# Altium schematic sheet Alt.SchDoc(Alt)
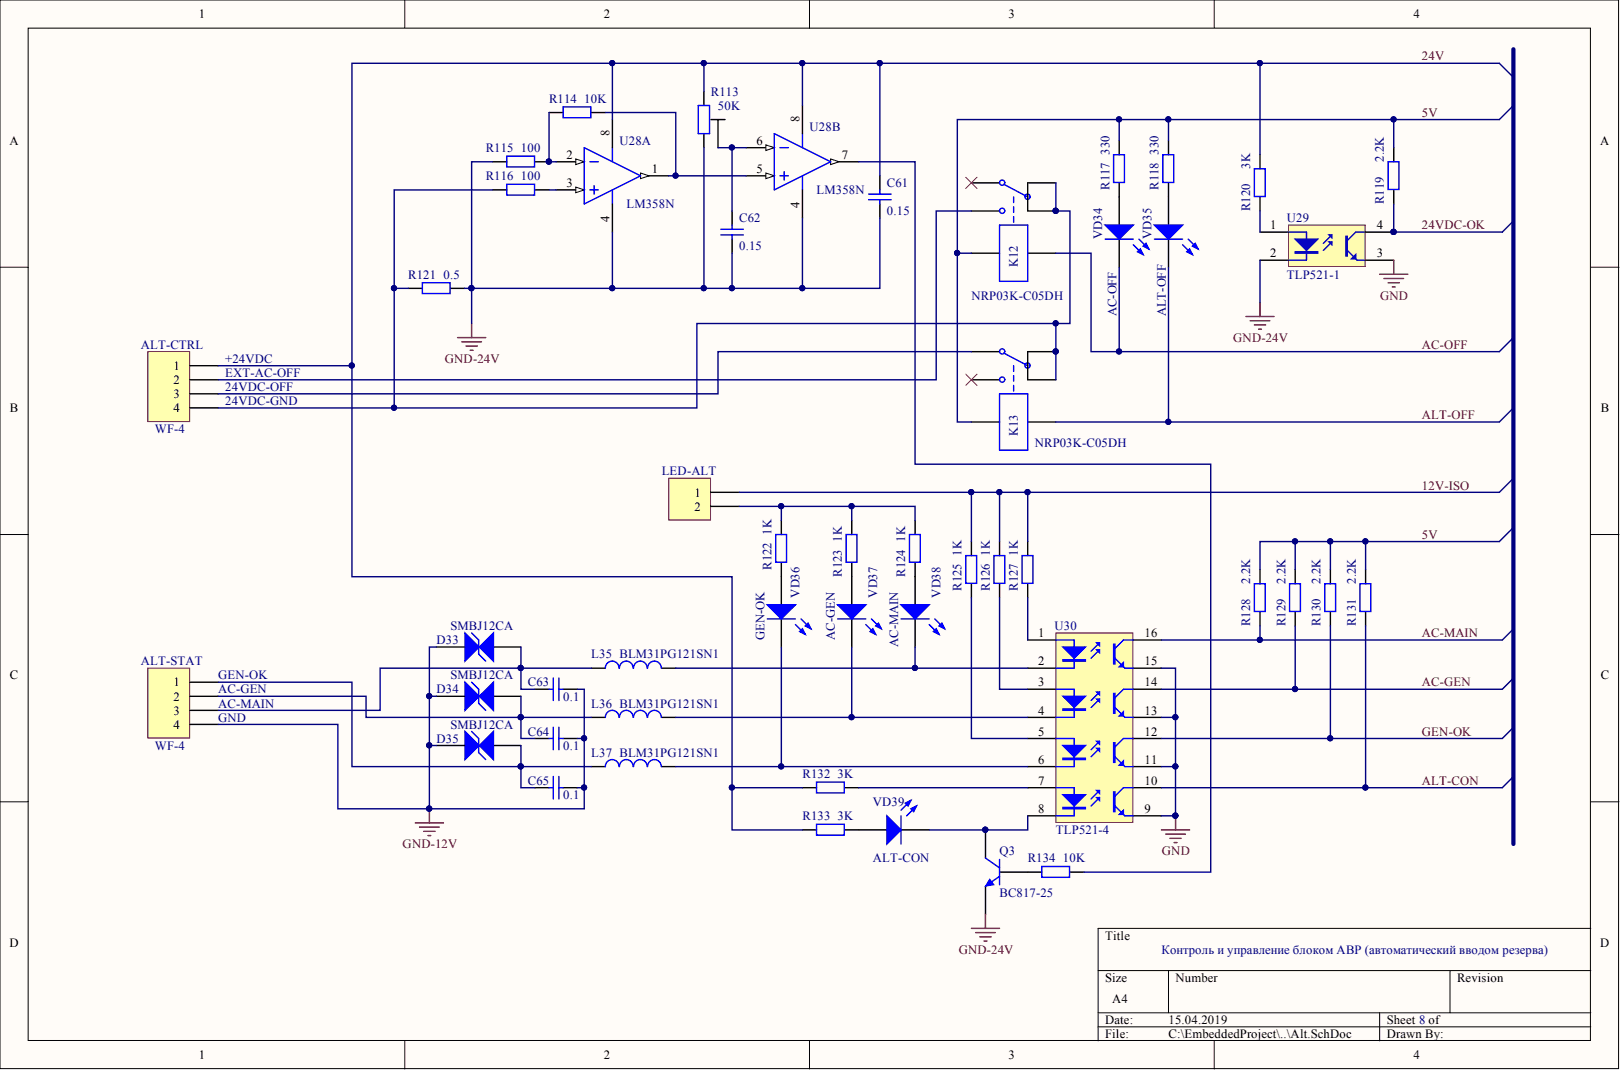
[diagram p0: Alt.SchDoc]

## Parts
ALT-CTRL  value WF-4  [WF-4]  (hidden comment: Header, 4-Pin)
ALT-STAT  value WF-4  [WF-4]  (hidden comment: Header, 4-Pin)
C61  value 0.15  [C1206]  (hidden comment: Cap)
C62  value 0.15  [C1206]  (hidden comment: Cap)
C63  value 0.1  [C1206]  (hidden comment: Cap)
C64  value 0.1  [C1206]  (hidden comment: Cap)
C65  value 0.1  [C1206]  (hidden comment: Cap)
D33  SMBJ12CA  [DO-214AA]
D34  SMBJ12CA  [DO-214AA]
D35  SMBJ12CA  [DO-214AA]
K12  value NRP03K-C05DH  [NRP03K-C05DH]  (hidden comment: Single-Pole Dual-Throw Relay)
K13  value NRP03K-C05DH  [NRP03K-C05DH]  (hidden comment: Single-Pole Dual-Throw Relay)
L35  value BLM31PG121SN1  [L1206]  (hidden comment: Ferrite Chip Beads)
L36  value BLM31PG121SN1  [L1206]  (hidden comment: Ferrite Chip Beads)
L37  value BLM31PG121SN1  [L1206]  (hidden comment: Ferrite Chip Beads)
LED-ALT  JP2  [HDR1X2]  (value not drawn)
Q3  value BC817-25  [SOT-23A_N]  (hidden comment: SOT23 NPN Silicon Planar General Purpose Transistor)
R113  value 50K  [RES-3296W]  (hidden comment: Resistor TAP)
R114  value 10K  [1206]  (hidden comment: Res2)
R115  value 100  [1206]  (hidden comment: Res2)
R116  value 100  [1206]  (hidden comment: Res2)
R117  value 330  [1206]  (hidden comment: Res2)
R118  value 330  [1206]  (hidden comment: Res2)
R119  value 2.2K  [1206]  (hidden comment: Res2)
R120  value 3K  [1206]  (hidden comment: Res2)
R121  value 0.5  [R1W CF-800]  (hidden comment: Res2)
R122  value 1K  [1206]  (hidden comment: Res2)
R123  value 1K  [1206]  (hidden comment: Res2)
R124  value 1K  [1206]  (hidden comment: Res2)
R125  value 1K  [1206]  (hidden comment: Res2)
R126  value 1K  [1206]  (hidden comment: Res2)
R127  value 1K  [1206]  (hidden comment: Res2)
R128  value 2.2K  [1206]  (hidden comment: Res2)
R129  value 2.2K  [1206]  (hidden comment: Res2)
R130  value 2.2K  [1206]  (hidden comment: Res2)
R131  value 2.2K  [1206]  (hidden comment: Res2)
R132  value 3K  [1206]  (hidden comment: Res2)
R133  value 3K  [1206]  (hidden comment: Res2)
R134  value 10K  [1206]  (hidden comment: Res2)
U28  value LM358N  [DIP-8]  (hidden comment: Low Power Dual Operational Amplifier, 8-pin MDIP)  (parts A,B)
U29  TLP521-1  [DIP-4 - OPTO]
U30  TLP521-4  [DIP-16 - OPTO]
VD34  AC-OFF  [LED1206 - RED]
VD35  ALT-OFF  [LED1206 - RED]
VD36  GEN-OK  [LED1206 - GREEN]
VD37  AC-GEN  [LED1206 - RED]
VD38  AC-MAIN  [LED1206 - GREEN]
VD39  ALT-CON  [LED1206 - YELOW]

## Nets
GND: U29.3 U30.9 U30.11 U30.13 U30.15
GND-12V: ALT-STAT.4 C63.2 C64.2 C65.2 D33.2 D34.2 D35.2
GND-24V: C61.1 C62.1 Q3.2 R113.3 R115.1 R121.1 U28.4 U29.2
5V: K12.5 K13.5 R117.1 R118.1 R119.1 R128.1 R129.1 R130.1 R131.1
12V-ISO: LED-ALT.1 R125.1 R126.1 R127.1
24V: ALT-CTRL.1 C61.2 R113.1 R120.1 R132.1 R133.2 U28.8
24VDC-OK: R119.2 U29.4
AC-GEN: R129.2 U30.14
AC-MAIN: R128.2 U30.16
AC-OFF: K12.4 VD34.2
ALT-CON: R131.2 U30.10
ALT-OFF: K13.4 VD35.2
GEN-OK: R130.2 U30.12
NetALT-CTRL_2: ALT-CTRL.2 K12.3
NetALT-CTRL_3: ALT-CTRL.3 K13.2
NetALT-CTRL_4: ALT-CTRL.4 K12.1 K12.6 K13.1 K13.6 R116.1 R121.2
NetALT-STAT_1: ALT-STAT.1 C65.1 D35.1 L37.1
NetALT-STAT_2: ALT-STAT.2 C64.1 D34.1 L36.1
NetALT-STAT_3: ALT-STAT.3 C63.1 D33.1 L35.1
NetC62_2: C62.2 R113.2 U28.6
NetL35_2: L35.2 U30.2 VD38.2
NetL36_2: L36.2 U30.4 VD37.2
NetL37_2: L37.2 U30.6 VD36.2
NetLED-ALT_2: LED-ALT.2 R122.1 R123.1 R124.1
NetQ3_1: Q3.1 R134.1
NetQ3_3: Q3.3 U30.8 VD39.2
NetR114_1: R114.1 R115.2 U28.2
NetR114_2: R114.2 U28.1 U28.5
NetR116_2: R116.2 U28.3
NetR117_2: R117.2 VD34.1
NetR118_2: R118.2 VD35.1
NetR120_2: R120.2 U29.1
NetR122_2: R122.2 VD36.1
NetR123_2: R123.2 VD37.1
NetR124_2: R124.2 VD38.1
NetR125_2: R125.2 U30.5
NetR126_2: R126.2 U30.3
NetR127_2: R127.2 U30.1
NetR132_2: R132.2 U30.7
NetR133_1: R133.1 VD39.1
NetR134_2: R134.2 U28.7
N00044:

Not connected: K12 2; K13 3

## Library data of the parts
ALT-CTRL ALT-STAT = Header 4: Component Kind: Standard; Description: Header, 4-Pin; LatestRevisionDate: 17-Jul-2002; LatestRevisionNote: Re-released for DXP Platform.; Library Name: Miscellaneous Connectors.IntLib; Signal Integrity: Connector; Publisher: Altium Limited
C61-C65 = Cap: Capacitor; Component Kind: Standard; LatestRevisionDate: 17-Jul-2002; LatestRevisionNote: Re-released for DXP Platform.; Library Name: Miscellaneous Devices.IntLib; PackageReference: RAD-0.3; Published: 8-Jun-2000; Signal Integrity: Cap; Simulation: CAP; Publisher: Altium Limited
D33-D35 = SMBJ12CA: Voltage suppressor; Component Kind: Standard; Library Name: Schlib1.SchLib; Value: SMBJ12CA
K12 K13 = NRP03K-C12DH: Component Kind: Standard; Description: Single-Pole Dual-Throw Relay; LatestRevisionDate: 17-Jul-2002; LatestRevisionNote: Re-released for DXP Platform.; Library Name: Schlib1.SchLib; PackageReference: MODULE5B; Published: 24-Mar-1999; Simulation: SPDTRELAY; Publisher: Altium Limited
L35-L37 = Inductor: Code_IEC: 1005; Code_JEITA: 0402; Component Kind: Standard; Description: Ferrite Chip Beads; LatestRevisionDate: 29-May-2009; LatestRevisionNote: IPC-7351 Footprint Added.; Library Name: Miscellaneous Devices.IntLib; PackageDescription: Chip Inductor; PackageReference: 0402-A; Published: 8-Jun-2000; Signal Integrity: Inductor; Simulation: INDUCTOR; Publisher: Altium Limited
LED-ALT = Header 2: Header, 2-Pin; value: Jumper; Component Kind: Standard; LatestRevisionDate: 17-Jul-2002; LatestRevisionNote: Re-released for DXP Platform.; Library Name: Miscellaneous Connectors.IntLib; Signal Integrity: Connector; Publisher: Altium Limited
Q3 = BC817-25: NPN General Purpose Amplifier; Component Kind: Standard; ComponentLink1Description: Manufacturer Link; ComponentLink1URL: http://www.fairchildsemi.com/; ComponentLink2Description: Discontinuation Notice; ComponentLink2URL: http://www.fairchildsemi.com/obsolete/obs.jsp; DatasheetVersion: 1997; LatestRevisionDate: 20-Nov-2009; LatestRevisionNote: IPC-7351 Footprint Added.; Library Name: FSC Discrete BJT.IntLib; PackageDescription: 3-Pin SOT-23 Package 0.95 mm Pitch, 2.3 mm Lead Span; PackageReference: SOT-23A; PackageVersion: Sep-1998; Published: 8-Jun-2000; Signal Integrity: BC817-25; Simulation: BC817-25; Publisher: Altium Limited
R113 = Res TAP: Code_IPC: RESC2012; Component Kind: Standard; Description: Resistor TAP; LatestRevisionDate: 29-May-2009; LatestRevisionNote: IPC-7351 Footprint Added.; Library Name: Schlib1.SchLib; PackageDescription: Chip Resistor 0805 Case Size; PackageReference: 6-0805; PackageVersion: Mar-2003; Published: 24-Mar-1999; Publisher: Altium Limited
R114-R134 = Res2: Resistor; Component Kind: Standard; LatestRevisionDate: 17-Jul-2002; LatestRevisionNote: Re-released for DXP Platform.; Library Name: Miscellaneous Devices.IntLib; PackageReference: AXIAL-0.4; Published: 8-Jun-2000; Signal Integrity: Res; Simulation: RESISTOR; Publisher: Altium Limited
U28 = LM358N: Manufacturer: National Semiconductor; CompType: Amplifiers; High-Reliability: Y; PackageTopMarking: NSUZXYTTE#*LM*358N; PowerWise: N; Code_JEDEC: MS-001-BA; Component Kind: Standard; ComponentLink1Description: Manufacturer URL; ComponentLink1URL: http://www.national.com/; ComponentLink2Description: LM358 Datasheet; ComponentLink2URL: http://www.national.com/ds/LM/LM358.pdf; ComponentLink3Description: LM358 Web Page; ComponentLink3URL: http://www.national.com/pf/LM/LM358.html; ComponentLink4Description: N08E Package Page; ComponentLink4URL: http://www.national.com/packaging/folders/n08e.html; DatasheetVersion: Oct-2005; Description: Low Power Dual Operational Amplifier, 8-pin MDIP; Library Name: National Semiconductor Amplifier.IntLib; PackageDescription: MDIP, .365 x .250 x .130in, 8 Lead, .100in Pitch; PackageReference: N08E; PackageVersion: revG, May-2006; PartNumber: LM358N; PinCount: 8; RoHS: N
U29 = TLP521-1: 1 consist of a photo−transistor; Component Kind: Standard; DatasheetVersion: Nov-2003; LatestRevisionDate: 04-Mar-2005; LatestRevisionNote: Sch pins 3 & 4 Swapped.; Library Name: Schlib1.SchLib; PackageReference: DIP-4; Published: 24-Mar-1999; Value: TLP521-1; Publisher: Altium Limited
U30 = TLP521-4: 4 consist of a photo−transistor; Component Kind: Standard; DatasheetVersion: Nov-2003; LatestRevisionDate: 04-Mar-2005; LatestRevisionNote: Sch pins 3 & 4 Swapped.; Library Name: Schlib1.SchLib; PackageReference: DIP-4; Published: 24-Mar-1999; value: TLP521-4; Publisher: Altium Limited
VD34 VD35 VD37 = LED0: SMD LED; value: RED; Component Kind: Standard; LatestRevisionDate: 08-Jul-2005; LatestRevisionNote: Mech Layer 1 removed.; Library Name: Miscellaneous Devices.IntLib; PackageReference: LED-0; Published: 8-Jun-2000; Simulation: LED0; Publisher: Altium Limited
VD36 VD38 = LED0: SMD LED; value: GREEN; Component Kind: Standard; LatestRevisionDate: 08-Jul-2005; LatestRevisionNote: Mech Layer 1 removed.; Library Name: Miscellaneous Devices.IntLib; PackageReference: LED-0; Published: 8-Jun-2000; Simulation: LED0; Publisher: Altium Limited
VD39 = LED0: SMD LED; value: ORANGE; Component Kind: Standard; LatestRevisionDate: 08-Jul-2005; LatestRevisionNote: Mech Layer 1 removed.; Library Name: Miscellaneous Devices.IntLib; PackageReference: LED-0; Published: 8-Jun-2000; Simulation: LED0; Publisher: Altium Limited
